# Altium schematic sheet [05] - NOR_FLASH.SchDoc
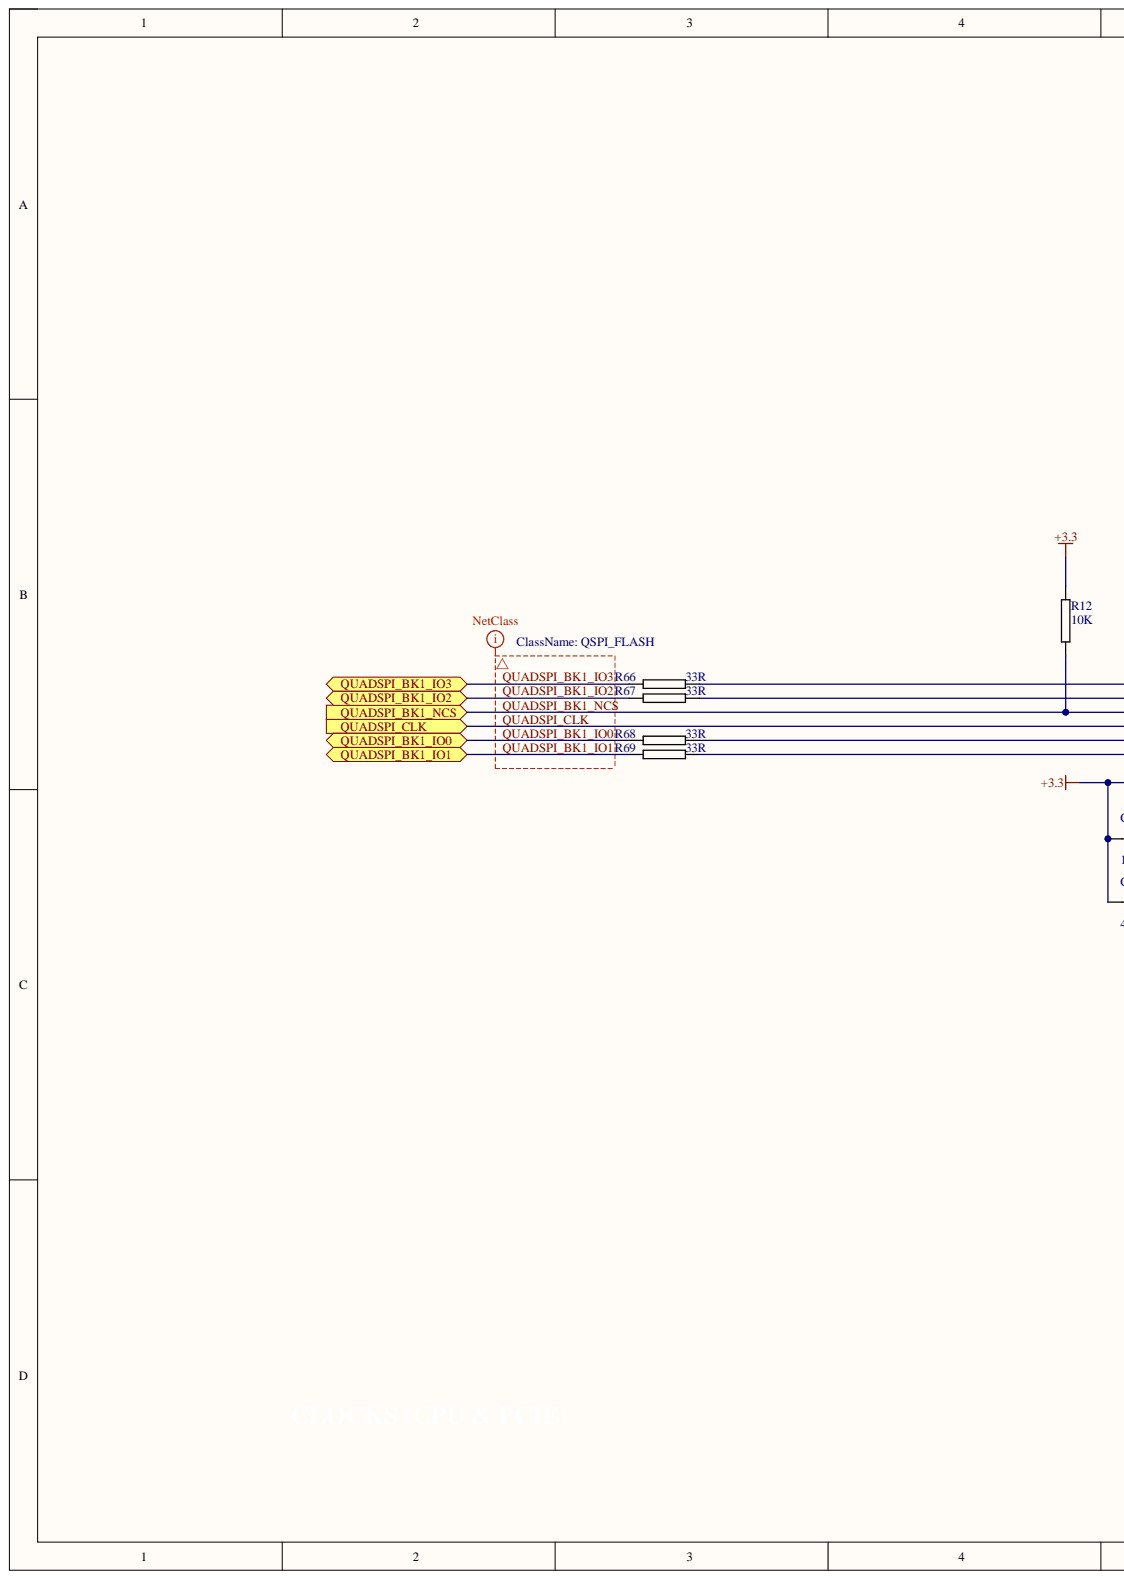
[diagram p0: [05] - NOR_FLASH.SchDoc, left]
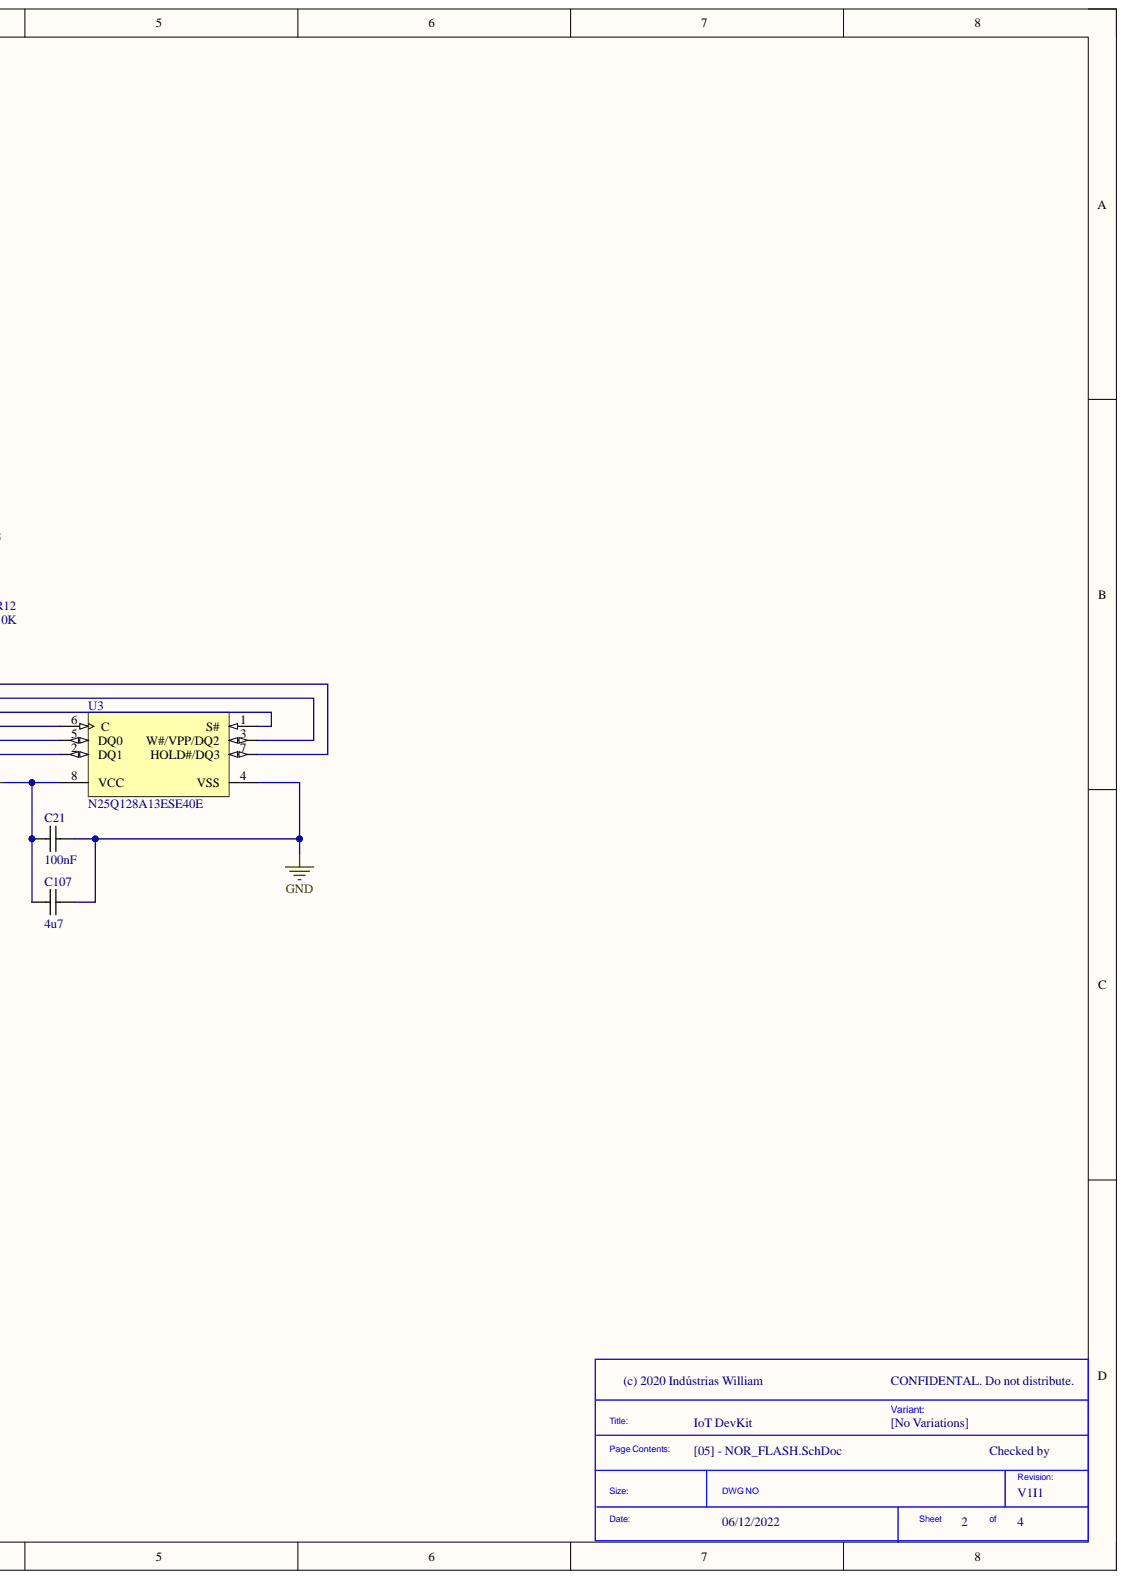
[diagram p1: [05] - NOR_FLASH.SchDoc, right]

## Parts
C21  100nF  [0603C-GENERIC]
C107  4u7  [0603C-GENERIC]
R12  10K  [0603R-10K]
R66  33R  [0603R-33]
R67  33R  [0603R-33]
R68  33R  [0603R-33]
R69  33R  [0603R-33]
U3  N25Q128A13ESE40E  [MICT-SE-8_N]

## Ports
QUADSPI_BK1_IO0, QUADSPI_BK1_IO1, QUADSPI_BK1_IO2, QUADSPI_BK1_IO3, QUADSPI_BK1_NCS, QUADSPI_CLK

## Nets
+3.3: C21.1 C107.1 R12.1 U3.8(VCC)
GND: C21.2 C107.2 U3.4(VSS)
QUADSPI_BK1_IO0: R68.1 ; port QUADSPI_BK1_IO0
QUADSPI_BK1_IO1: R69.1 ; port QUADSPI_BK1_IO1
QUADSPI_BK1_IO2: R67.1 ; port QUADSPI_BK1_IO2
QUADSPI_BK1_IO3: R66.1 ; port QUADSPI_BK1_IO3
QUADSPI_BK1_NCS: R12.2 U3.1(S#) ; port QUADSPI_BK1_NCS
QUADSPI_CLK: U3.6(C) ; port QUADSPI_CLK
NetR66_2: R66.2 U3.7(HOLD#/DQ3)
NetR67_2: R67.2 U3.3(W#/VPP/DQ2)
NetR68_2: R68.2 U3.5(DQ0)
NetR69_2: R69.2 U3.2(DQ1)

## Library data of the parts
C21 = 0603C-100NF-25V-X7R-TDK: CAPACITOR 0603 CERAMIC; Manufacturer: TDK Corporation; Manufacturer Part Number: C1608X7R1E104K; Reel: C12; Component Kind: Standard; Library Name: vh_altium_library.intLib; Supplier 1: Digi-Key; Supplier Part Number 1: 445-1316-2-ND
C107 = 0603C-4U7-10V-X5R-SAM: CAPACITOR 0603 CERAMIC; Reel: C46; Component Kind: Standard; Library Name: vh_altium_library.intLib
R12 = 0603R-10K: GENERIC RESISTOR 0603; Manufacturer: Stackpole Electronics Inc; Manufacturer Part Number: RMCF 1/16 10K 1% R; Power (Watts): 0.1W, 1/10W; Reel: R21; Resistance In Ohms: 10.0K; Temperature Coefficient: ±100ppm/°C; Tolerance: ±1%; Case: 0603 (1608 metric); Category: Resistors; Component Kind: Standard; Composition: Thick Film; Lead Style: Surface Mount (SMD - SMT); Library Name: vh_altium_library.intLib; Packaging: Digi-Reel®; RoHS: RoHS Compliant; Supplier: Digi-Key; Supplier 1: Digi-Key; Supplier Part Number: RMCF1/1610KFRDKR-ND; Supplier Part Number 1: RMCF0603FT10K0DKR-ND
R66-R69 = 0603R-33R: GENERIC RESISTOR 0603; Manufacturer: Stackpole Electronics Inc; Manufacturer Part Number: RMC 1/16 33 1% G; Power (Watts): 0.1W, 1/10W; Reel: R18; Resistance In Ohms: 33.0; Temperature Coefficient: ±100ppm/°C; Tolerance: ±1%; Case: 0603 (1608 metric); Category: Resistors; Component Kind: Standard; Composition: Thick Film; Lead Style: Surface Mount (SMD - SMT); Library Name: vh_altium_library.intLib; Packaging: Tape & Reel (TR); RoHS: RoHS Compliant; Supplier: Digi-Key; Supplier 1: Digi-Key; Supplier Part Number: RMC1/1633FG-ND; Supplier Part Number 1: RMCF0603FT33R0TR-ND
U3 = N25Q128A13ESE40E: 128 Mb (x1/x2/x4) Serial NOR Flash Memory with Multi I/O, 108 MHz, 2.7 to 3.6 V, 8-pin SO8 Wide (SE), Tray; Manufacturer: Micron; Part Family: N25Q; Density: 128Mb; Speed: 108 MHz; Voltage: 2.7V-3.6V; Applications: Embedded; Component Kind: Standard; ComponentLink1Description: Manufacturer URL; ComponentLink1URL: http://www.micron.com/; ComponentLink2Description: Datasheet; ComponentLink2URL: http://www.micron.com/~/media/Documents/Products/Data%20Sheet/NOR%20Flash/Serial%20NOR/N25Q/n25q_128mb_3v_65nm.pdf; ComponentLink3Description: Package Specification; ComponentLink3URL: http://www.micron.com/~/media/Documents/Products/Data%20Sheet/NOR%20Flash/Serial%20NOR/N25Q/n25q_32mb_1_8v_65nm.pdf; DatasheetVersion: Rev. L; Library Name: Micron Memory Serial NOR Flash.IntLib; Mounting Technology: Surface Mount; Package: SO8 Wide; PackageDescription: 8-Pin SO Wide, 5.29 X 5.29 mm, Pitch 1.27 mm; PackageReference: MICT-SE-8; PackageVersion: Rev. E; Part Status: Production; PartNumber: N25Q128A13ESE40E; RoHS: Yes; Type: Multi I/O; Width: x1/x2/x4
